# Altium schematic sheet Screen_24.SchDoc
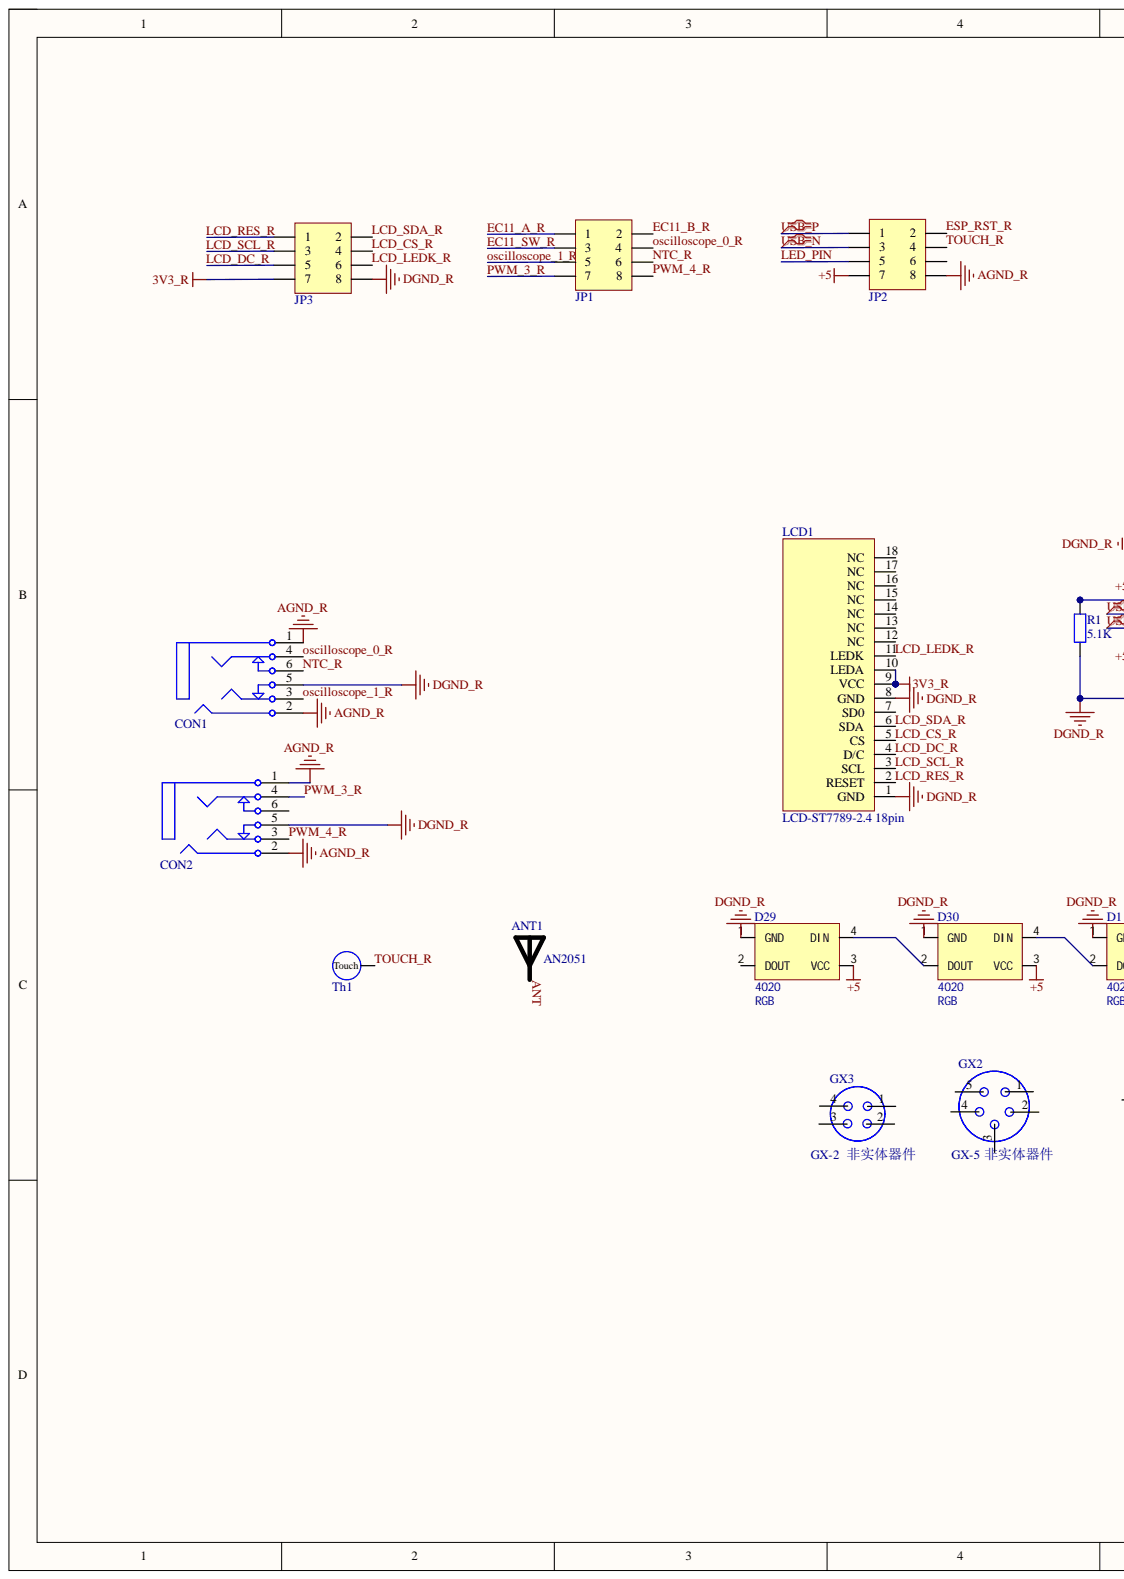
[diagram p0: Screen_24.SchDoc, left]
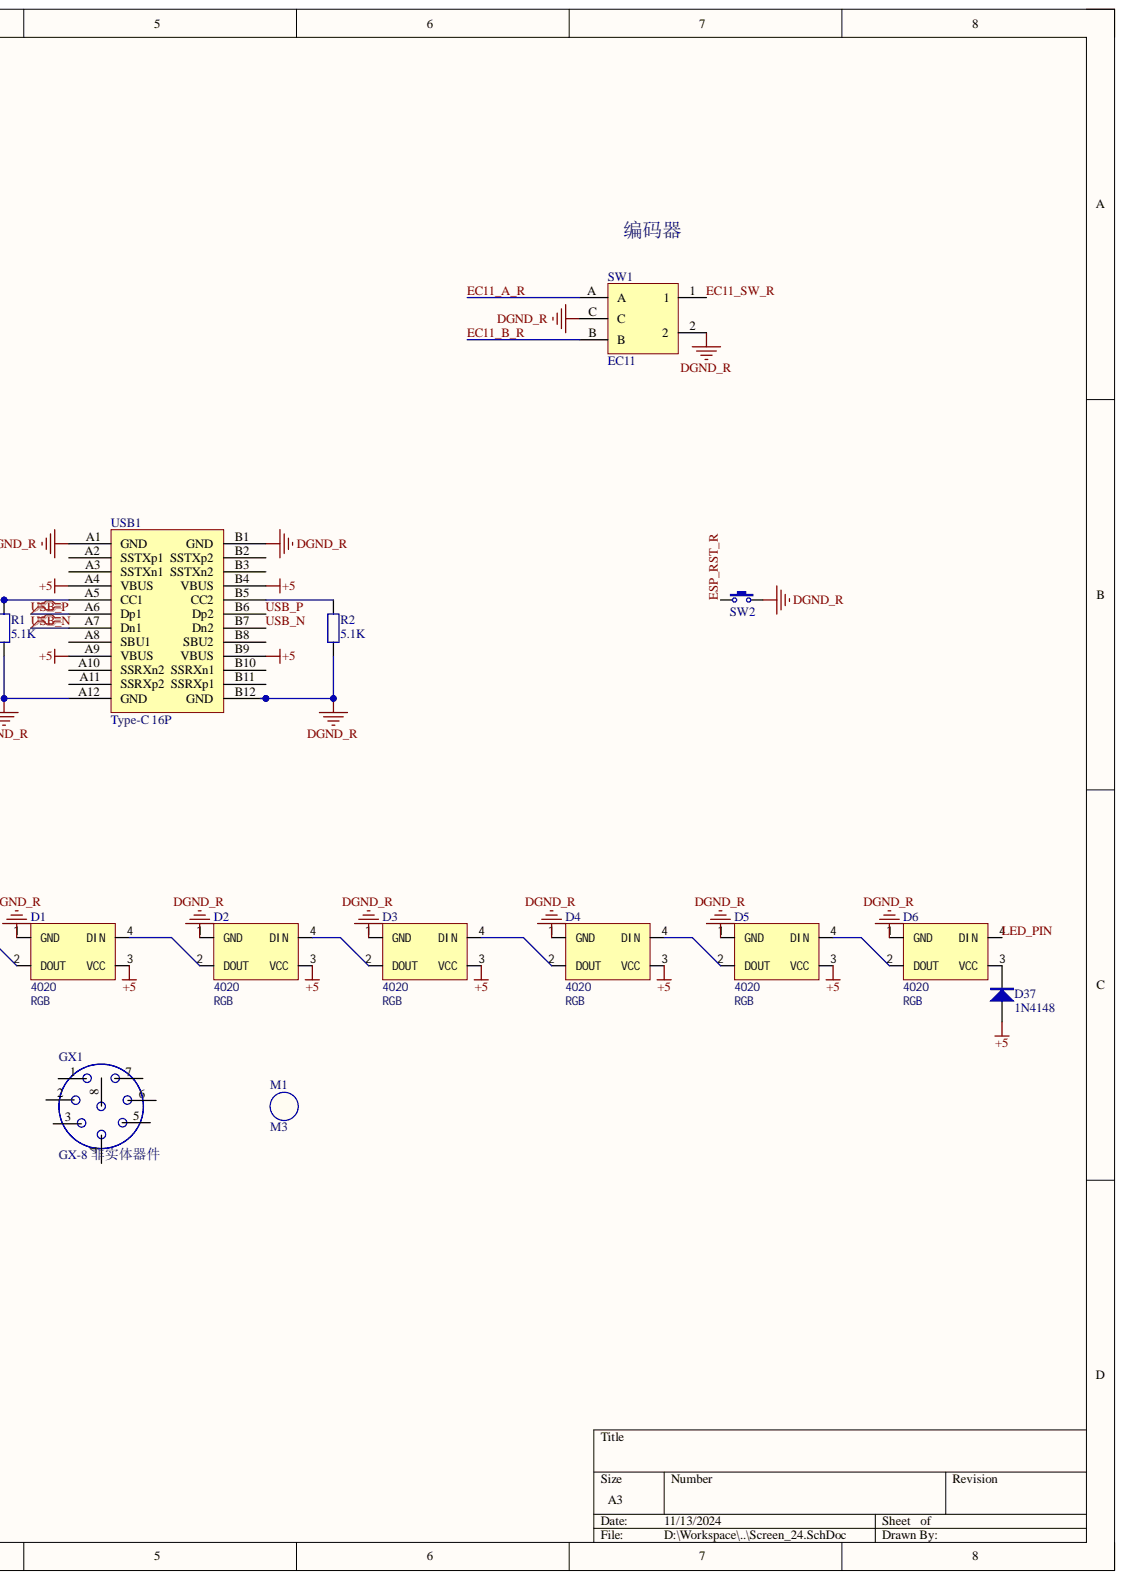
[diagram p1: Screen_24.SchDoc, right]

## Parts
ANT1  AN2051  [ANT-Rainsun-AN2051]
CON1  PJ342  [PJ342A]  (value not drawn)
CON2  PJ342  [PJ342A]  (value not drawn)
D1  RGB  Type: 4020  [LED_4020_WS2812B]
D2  RGB  Type: 4020  [LED_4020_WS2812B]
D3  RGB  Type: 4020  [LED_4020_WS2812B]
D4  RGB  Type: 4020  [LED_4020_WS2812B]
D5  RGB  Type: 4020  [LED_4020_WS2812B]
D6  RGB  Type: 4020  [LED_4020_WS2812B]
D29  RGB  Type: 4020  [LED_4020_WS2812B]
D30  RGB  Type: 4020  [LED_4020_WS2812B]
D37  1N4148  [SOD-323]
GX1  GX-8 非实体器件  [GX16-8_Simple]
GX2  GX-5 非实体器件  [GX12-5_Simple]
GX3  GX-2  非实体器件  [GX12-4MM]
JP1  1.27 4*2P插座 立式贴片  [HDR1.27-M-LS-2x4P]  (value not drawn)
JP2  1.27 4*2P插座 立式贴片  [HDR1.27-M-LS-2x4P]  (value not drawn)
JP3  1.27 4*2P插座 立式贴片  [HDR1.27-M-LS-2x4P]  (value not drawn)
LCD1  LCD-ST7789-2.4 18pin  [LCD-ST7789-2.4]
M1  M3  [FIXED-M3]
R1  5.1K  [R 0603]
R2  5.1K  [R 0603]
SW1  EC11  [EC11-DEEP]
SW2  3x6x3.5  [TSW 3*6*4.3-S-W]  (value not drawn)
Th1  康铜丝 线径1.5*10mm脚距  [TOUCH]  (value not drawn)
USB1  Type-C 16P  [USB Type-C-16Pin_A]

## Nets
3V3_R: JP3.7 LCD1.9(VCC) LCD1.10(LEDA)
+5: D1.3(VCC) D2.3(VCC) D3.3(VCC) D4.3(VCC) D5.3(VCC) D29.3(VCC) D30.3(VCC) D37.1 JP2.7 USB1.A4(VBUS) USB1.A9(VBUS) USB1.B4(VBUS) USB1.B9(VBUS)
AGND_R: CON1.1 CON1.2 CON2.1 CON2.2 JP2.8
DGND_R: CON1.5 CON2.5 D1.1(GND) D2.1(GND) D3.1(GND) D4.1(GND) D5.1(GND) D6.1(GND) D29.1(GND) D30.1(GND) JP3.8 LCD1.1(GND) LCD1.8(GND) R1.1 R2.1 SW1.2 SW1.C SW2.2 USB1.A1(GND) USB1.A12(GND) USB1.B1(GND) USB1.B12(GND)
ANT: ANT1.1
EC11_A_R: JP1.1 SW1.A
EC11_B_R: JP1.2 SW1.B
EC11_SW_R: JP1.3 SW1.1
ESP_RST_R: JP2.2 SW2.1
LCD_CS_R: JP3.4 LCD1.5(CS)
LCD_DC_R: JP3.5 LCD1.4(D/C)
LCD_LEDK_R: JP3.6 LCD1.11(LEDK)
LCD_RES_R: JP3.1 LCD1.2(RESET)
LCD_SCL_R: JP3.3 LCD1.3(SCL)
LCD_SDA_R: JP3.2 LCD1.6(SDA)
LED_PIN: D6.4(DIN) JP2.5
NTC_R: CON1.6 JP1.6
oscilloscope_0_R: CON1.4 JP1.4
oscilloscope_1_R: CON1.3 JP1.5
PWM_3_R: CON2.4 JP1.7
PWM_4_R: CON2.3 JP1.8
TOUCH_R: JP2.4 Th1.1
USB_N: JP2.3 USB1.A7(Dn1) USB1.B7(Dn2)
USB_P: JP2.1 USB1.A6(Dp1) USB1.B6(Dp2)
NetD1_2: D1.2(DOUT) D30.4(DIN)
NetD1_4: D1.4(DIN) D2.2(DOUT)
NetD2_4: D2.4(DIN) D3.2(DOUT)
NetD3_4: D3.4(DIN) D4.2(DOUT)
NetD4_4: D4.4(DIN) D5.2(DOUT)
NetD5_4: D5.4(DIN) D6.2(DOUT)
NetD6_3: D6.3(VCC) D37.2
NetD29_4: D29.4(DIN) D30.2(DOUT)
NetR1_2: R1.2 USB1.A5(CC1)
NetR2_2: R2.2 USB1.B5(CC2)

Not connected: CON2 6; D29 2(DOUT); GX1 1 2 3 4 5 6 7 8; GX2 1 2 3 4 5; GX3 1 2 3 4; JP2 6; LCD1 7(SD0) 12(NC) 13(NC) 14(NC) 15(NC) 16(NC) 17(NC) 18(NC); USB1 A2(SSTXp1) A3(SSTXn1) A8(SBU1) A10(SSRXn2) A11(SSRXp2) B2(SSTXp2) B3(SSTXn2) B8(SBU2) B10(SSRXn1) B11(SSRXp1)

## Library data of the parts
ANT1 = ANT: 2.4G陶瓷天线; BOM名称: DC稳压芯片; 版权归属: 源创客; Component Kind: Standard; 学习资源: www.ooocke.com; Library Name: SuperHeat.SCHLIB
CON1 CON2 = PJ342: 3.5耳机插座,6Pin; BOM名称: 耳机插座; Component Kind: Standard; Library Name: SuperHeat.SCHLIB
D1-D6 D29 D30 = LED_4020_WS2812B: LED; Component Kind: Standard; Value: RGB; Library Name: SuperHeat.SCHLIB
D37 = 1N4148: 高速开关二极管; BOM名称: 高速开关二极管; Component Kind: Standard; Library Name: SuperHeat.SCHLIB
GX1 = GX-8: GX16-x航空头螺纹孔  非实体器件; BOM名称: 引线焊盘; Component Kind: Standard; Library Name: SuperHeat.SCHLIB
GX2 = GX-5: GX12-x航空头螺纹孔  非实体器件; BOM名称: 引线焊盘; Component Kind: Standard; Library Name: SuperHeat.SCHLIB
GX3 = GX-4: 4MM香蕉头孔一对; BOM名称: 引线焊盘; Component Kind: Standard; Library Name: SuperHeat.SCHLIB
JP1-JP3 = Header 4X2: 4*2P接插件; BOM名称: 4*2P接插件; Component Kind: Standard; Library Name: SuperHeat.SCHLIB
LCD1 = LCD-ST7789_24: ST7789驱动显示屏; Component Kind: Standard; Library Name: SuperHeat.SCHLIB
M1 = M3: 铜柱孔; BOM名称: 铜柱孔; Component Kind: Standard; Library Name: SuperHeat.SCHLIB
R1 R2 = R: 贴片电阻; 版权归属: 源创客; BOM名称: 贴片电阻; Component Kind: Standard; 学习资源: www.ooocke.com; Library Name: SuperHeat.SCHLIB
SW1 = EC11: 数字开关编码器; BOM名称: 数字编码开关; 版权归属: 源创客; Component Kind: Standard; 学习资源: www.ooocke.com; Library Name: SuperHeat.SCHLIB
SW2 = TSW 3x6: 3x6轻触开关; 版权归属: 源创客; BOM名称: 3x6轻触开关; Component Kind: Standard; 学习资源: www.ooocke.com; Library Name: SuperHeat.SCHLIB
Th1 = Touch-1P: 触控焊盘; BOM名称: 触控焊盘; Component Kind: Standard; Library Name: SuperHeat.SCHLIB
USB1 = USB-C/S: USB-C型母接口; BOM名称: USB-C型母接口; Component Kind: Standard; Library Name: SuperHeat.SCHLIB
